# Altium schematic sheet Drofnats.SchDoc
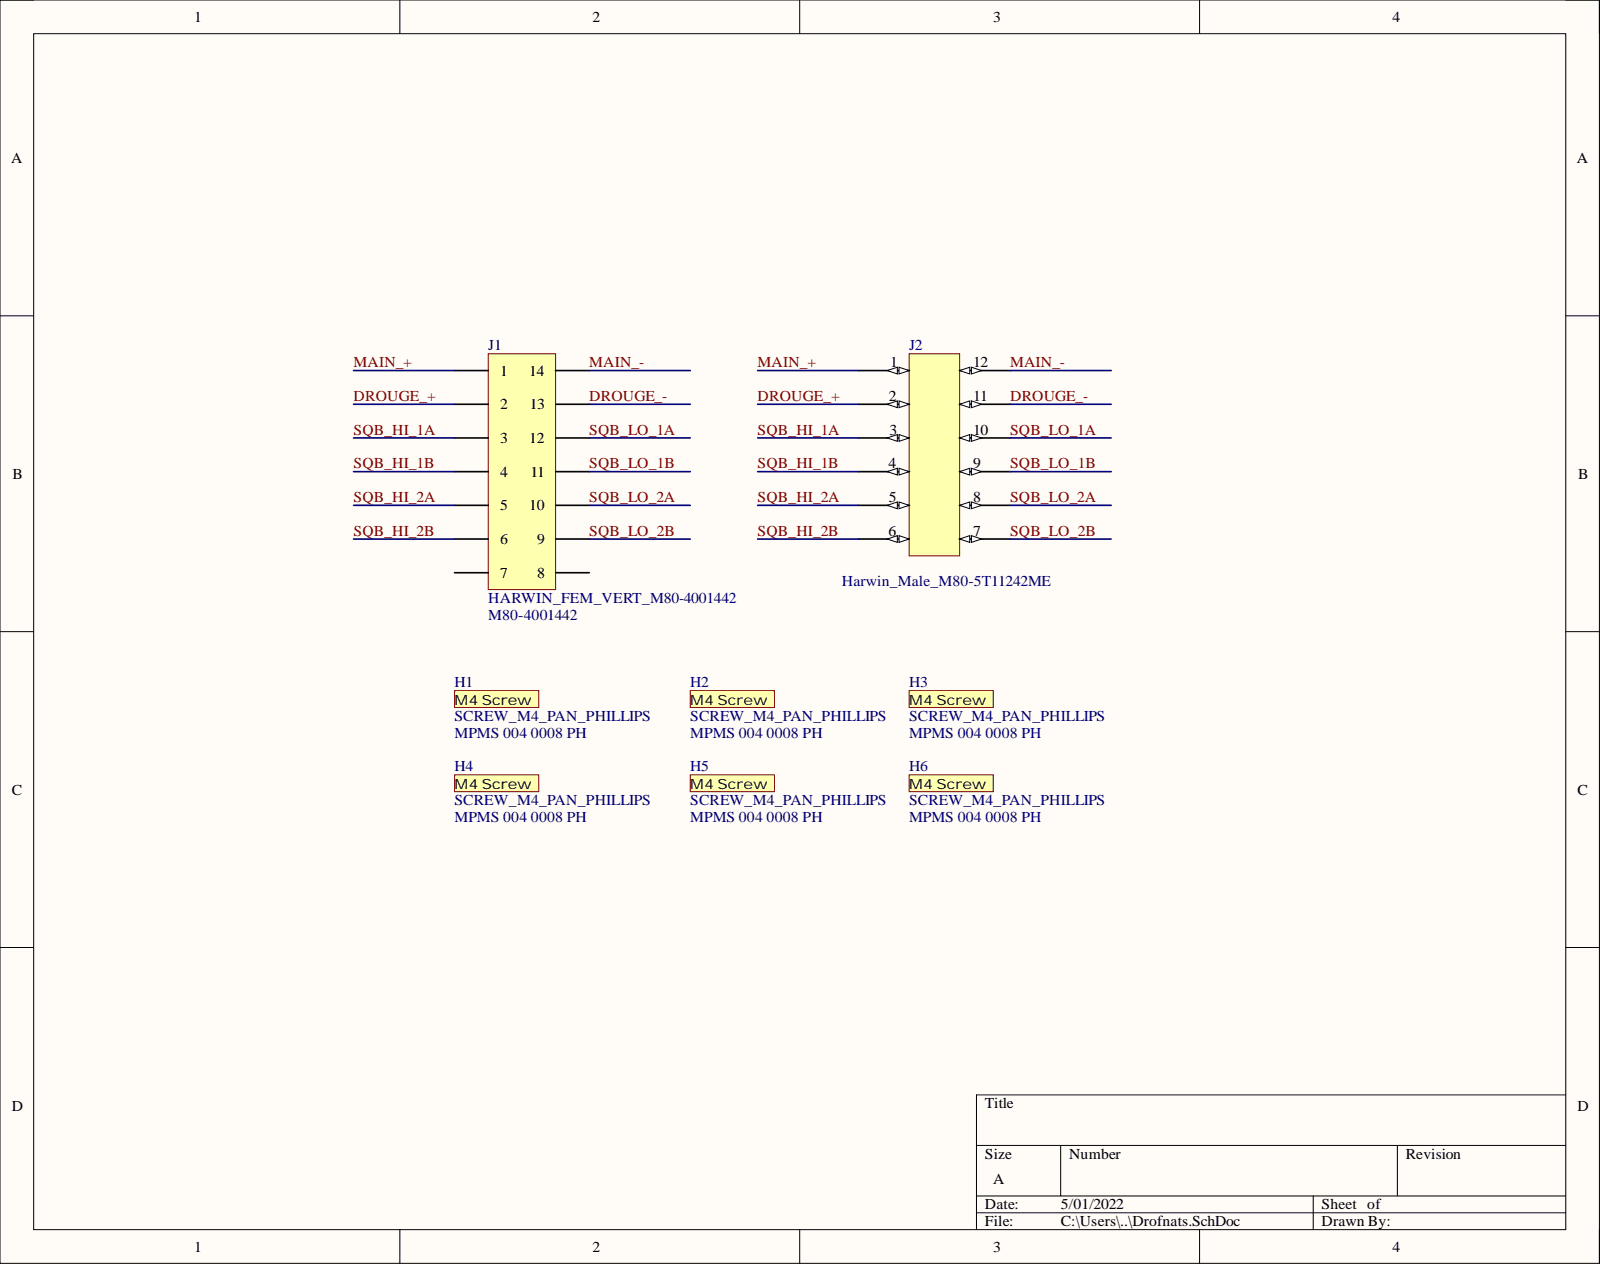
[diagram p0: Drofnats.SchDoc]

## Parts
H1  SCREW_M4_PAN_PHILLIPS  Thread Size: M4  [SCREW_M4]
H2  SCREW_M4_PAN_PHILLIPS  Thread Size: M4  [SCREW_M4]
H3  SCREW_M4_PAN_PHILLIPS  Thread Size: M4  [SCREW_M4]
H4  SCREW_M4_PAN_PHILLIPS  Thread Size: M4  [SCREW_M4]
H5  SCREW_M4_PAN_PHILLIPS  Thread Size: M4  [SCREW_M4]
H6  SCREW_M4_PAN_PHILLIPS  Thread Size: M4  [SCREW_M4]
J1  HARWIN_FEM_VERT_M80-4001442  Manufacturer Part Number: M80-4001442  [HARWIN_M80-4001442]
J2  Harwin M80-5T11242ME  [HARWIN-M80-5T11242ME]  (value not drawn)

## Nets
DROUGE_+: J1.2 J2.2
DROUGE_-: J1.13 J2.11
MAIN_+: J1.1 J2.1
MAIN_-: J1.14 J2.12
SQB_HI_1A: J1.3 J2.3
SQB_HI_1B: J1.4 J2.4
SQB_HI_2A: J1.5 J2.5
SQB_HI_2B: J1.6 J2.6
SQB_LO_1A: J1.12 J2.10
SQB_LO_1B: J1.11 J2.9
SQB_LO_2A: J1.10 J2.8
SQB_LO_2B: J1.9 J2.7

Not connected: J1 7 8

## Library data of the parts
H1-H6 = CMP-054-0009-1: MACHINE SCREW PAN PHILLIPS M4; Manufacturer: B&F Fastener Supply; Manufacturer Part Number: MPMS 004 0008 PH; Head Diameter: 0.308" (7.82mm); Head Height: 0.120" (3.05mm); Plating: Zinc; Screw Head Type: Pan Head; Component Kind: Standard; ComponentLink1Description: Datasheet; ComponentLink1URL: http://www.fasnetdirect.com/refguide/MetD7985a.pdf; Design Item ID: SCREW_M4_PAN_PHILLIPS; Drive Type: Phillips; Features: -; Length - Below Head: 0.315" (8.00mm); Length - Overall: 0.435" (11.05mm); Material: -; Pricing 1: 100=0.0756, 1000=0.06048 (USD); RoHS: RoHS non-compliant; Source: mechanical.SchLib; Stock 1: 2800; Library Name: Stanford Student Space Initiative
J1 = CMP-030-0080-1: 7+7 POS FEM +J/S 3mm TAIL TIN; Manufacturer: Harwin; Connector Type: Receptacle; Number of Positions: 14; Number of Positions Loaded: All; Pitch: 0.079" (2.00mm); Color: Black; Component Kind: Standard; ComponentLink1Description: https://datasheet.ciiva.com/8051/10217-8051606.pdf; ComponentLink1URL: https://datasheet.ciiva.com/8051/10217-8051606.pdf; ComponentLink2Description: https://datasheet.ciiva.com/11749/0900766b80da66b3-11749902.pdf; ComponentLink2URL: https://datasheet.ciiva.com/11749/0900766b80da66b3-11749902.pdf; ComponentLink3Description: https://datasheet.ciiva.com/9480/m80-400-9480223.pdf; ComponentLink3URL: https://datasheet.ciiva.com/9480/m80-400-9480223.pdf; Contact Finish: Gold; Contact Finish Thickness: 12µin (0.30µm); Contact Type: Female Socket; Design Item ID: HARWIN_FEM_VERT_M80-4001442; Family: Rectangular Connectors - Headers, Receptacles, Female Sockets; Fastening Type: -; Features: Jackscrews; Mounting Type: Through Hole; Number of Rows: 2; Packaging: Tube; Pricing 1: 1=12.82, 10=11.66, 50=10.49, 100=9.91, 1000=7.75, 10000=7.75 (USD); Row Spacing: 0.079" (2.00mm); Series: Datamate J-Tek; Source: connector.SchLib; Stock 1: 66; Termination: Solder; Library Name: Stanford Student Space Initiative
J2 = CMP-00034-5: 6+6 Pos. Male DIL Vertical Throughboard Conn. 101Lok; Component Kind: Standard; Library Name: Stanford Student Space Initiative
